# Altium schematic sheet relay.SchDoc
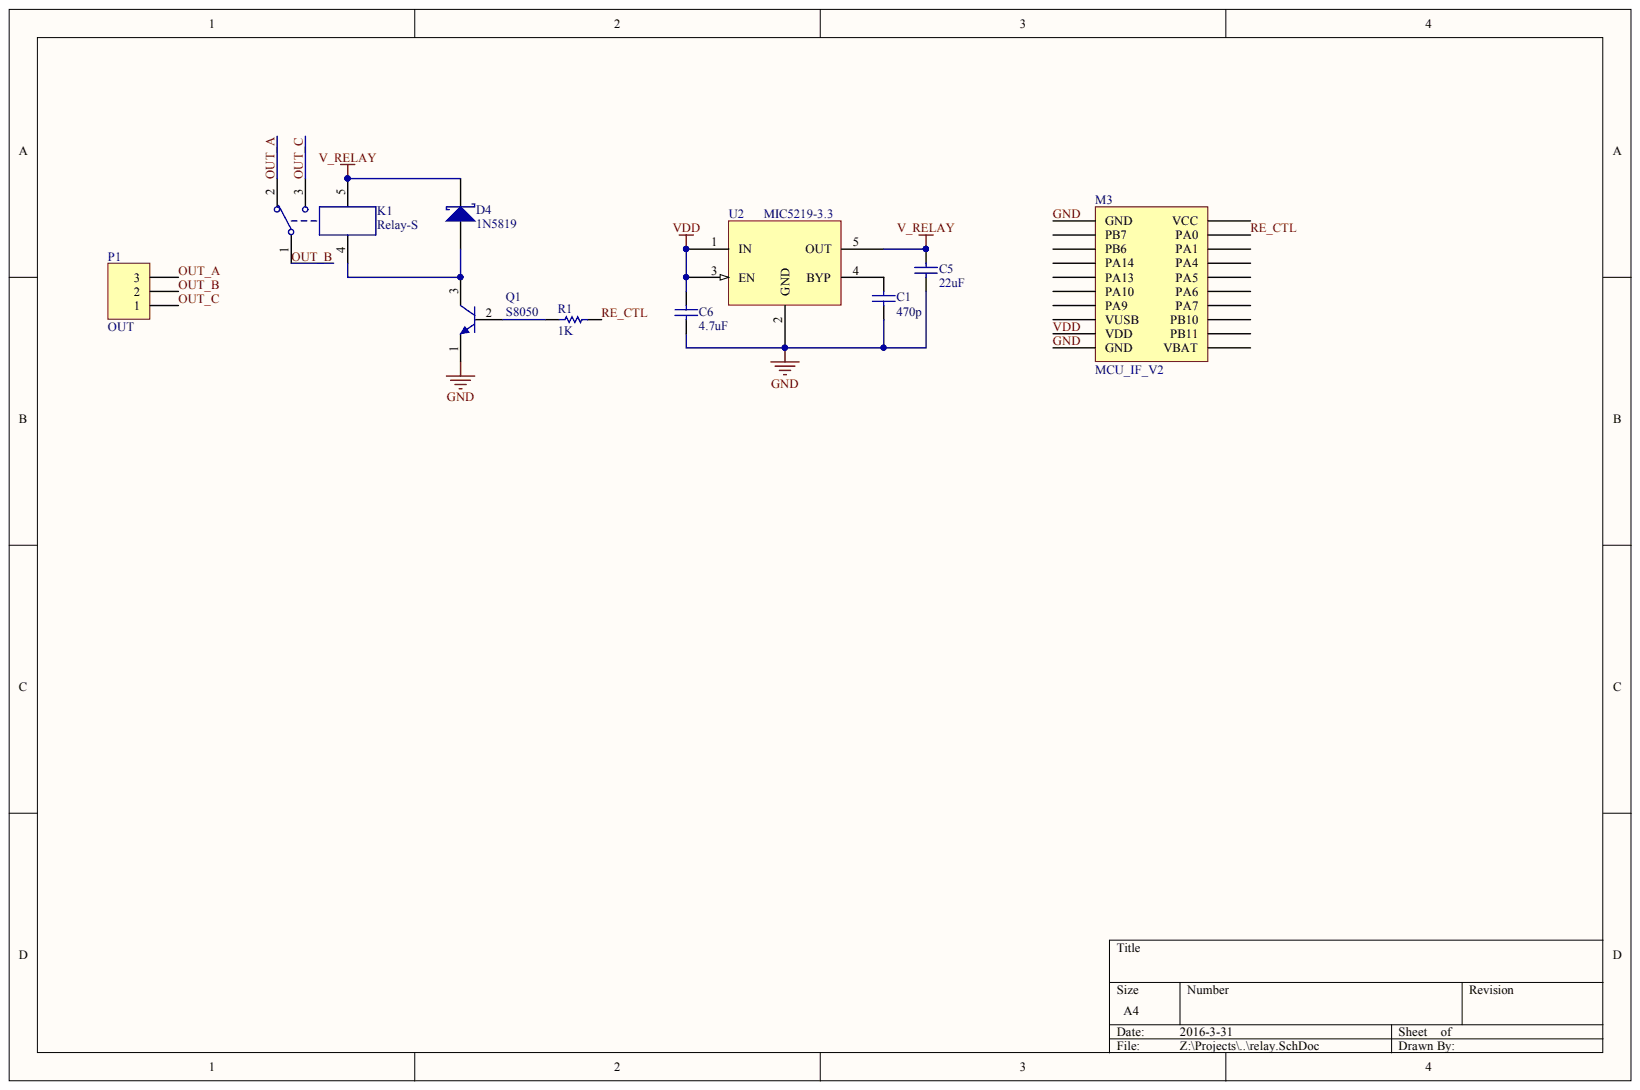
[diagram p0: relay.SchDoc]

## Parts
C1  value 470p  (hidden comment: Cap Semi)
C5  value 22uF  (hidden comment: Cap Semi)
C6  value 4.7uF  (hidden comment: Cap Semi)
D4  1N5819
K1  Relay-S
M3  MCU_IF_V2
P1  OUT
Q1  S8050
R1  value 1K  (hidden comment: Res Semi)
U2  MIC5219-3.3

## Nets
V_RELAY: C5.1 D4.2 K1.5 U2.5(OUT)
GND: C1.2 C5.2 C6.2 M3.1(GND) M3.10(GND) Q1.1 U2.2(GND)
OUT_A: K1.2 P1.3
OUT_B: K1.1 P1.2
OUT_C: K1.3 P1.1
RE_CTL: M3.19(PA0) R1.2
VDD: C6.1 M3.9(VDD) U2.1(IN) U2.3(EN)
NetC1_1: C1.1 U2.4(BYP)
NetD4_1: D4.1 K1.4 Q1.3
NetQ1_2: Q1.2 R1.1

Not connected: M3 2(PB7) 3(PB6) 4(PA14) 5(PA13) 6(PA10) 7(PA9) 8(VUSB) 11(VBAT) 12(PB11) 13(PB10) 14(PA7) 15(PA6) 16(PA5) 17(PA4) 18(PA1) 20(VCC)

## Library data of the parts
C1 C5 C6 D4 K1 M3 P1 Q1 R1 U2 = (no symbol name)
  C1 C5 C6: Published: 8-Jun-2000; LatestRevisionDate: 29-May-2009; LatestRevisionNote: IPC-7351 Footprint Added.; PackageReference: C1206; PackageDescription: Chip Capacitor
  D4: Published: 8-Jun-2000; LatestRevisionDate: 17-Jul-2002; LatestRevisionNote: Re-released for DXP Platform.
  K1: Published: 24-Mar-1999; LatestRevisionDate: 17-Jul-2002; LatestRevisionNote: Re-released for DXP Platform.; PackageReference: MODULE5B
  P1: LatestRevisionDate: 17-Jul-2002; LatestRevisionNote: Re-released for DXP Platform.
  Q1: Published: 8-Jun-2000; LatestRevisionDate: 15-Jan-2003; LatestRevisionNote: PCB Footprint 'BCY-W3/D4.7' replaced; DatasheetDocument: 1997; PackageDocument: Sep-1998; PackageReference: TO-92A; Code_JEDEC: TO-92A; ComponentLink1Description: Manufacturer Link; ComponentLink1URL: http://www.fairchildsemi.com/; ComponentLink2Description: Datasheet; ComponentLink2URL: http://www.fairchildsemi.com/ds/2N%2F2N3904.pdf
  R1: Published: 8-Jun-2000; LatestRevisionDate: 17-Jul-2002; LatestRevisionNote: Re-released for DXP Platform.; PackageReference: AXIAL-0.5
  C1 C5 C6 D4 K1 P1 Q1 R1: Publisher: Altium Limited
